# Altium schematic sheet interface_com_usuario.SchDoc
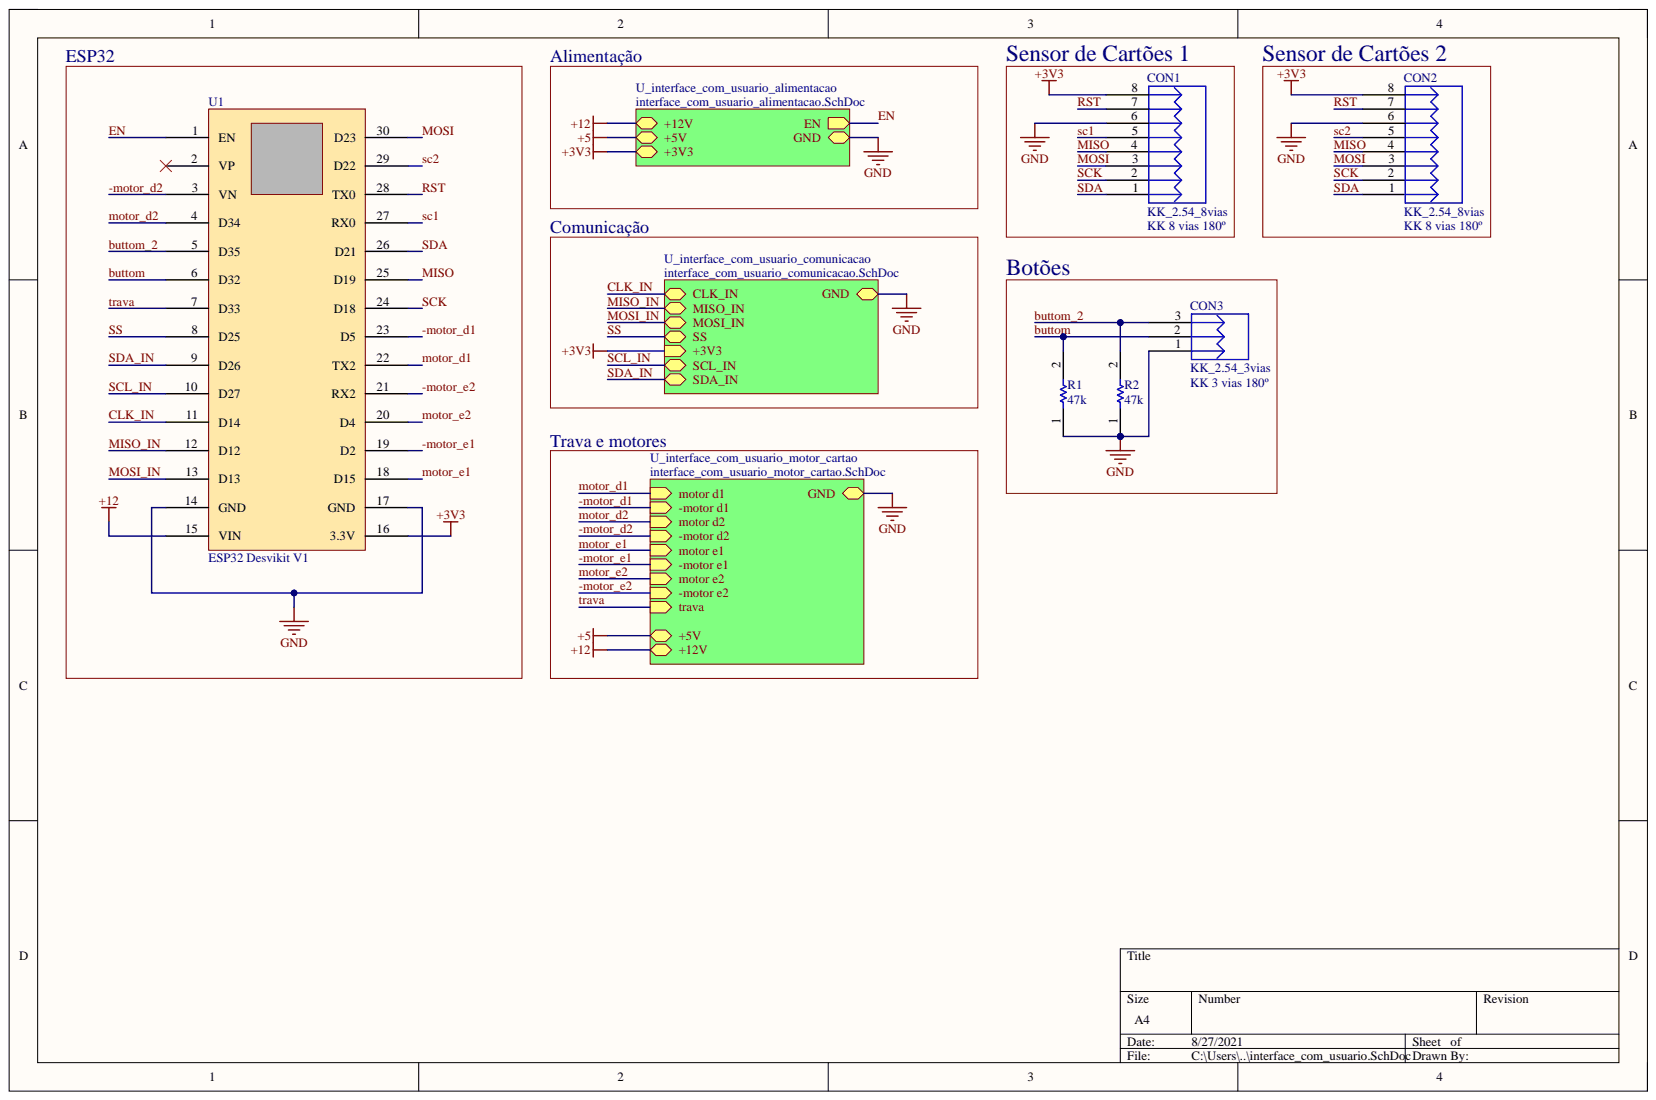
[diagram p0: interface_com_usuario.SchDoc]

## Parts
CON1  KK_2.54_8vias  Valor: KK 8 vias 180º  [KK_8vias_180°]
CON2  KK_2.54_8vias  Valor: KK 8 vias 180º  [KK_8vias_180°]
CON3  KK_2.54_3vias  Valor: KK 3 vias 180º  [KK_3vias_180º]
R1  47k  [RESC3216X60N]
R2  47k  [RESC3216X60N]
U1  value ESP32 Desvikit V1  [ESP32_Desvikit_v1]  (hidden comment: microcontrolador)

## Nets
+3V3: CON1.8 CON2.8 U1.16(3.3V)
+12: U1.15(VIN)
GND: CON1.6 CON2.6 CON3.1 R1.1 R2.1 U1.14(GND) U1.17(GND)
-motor_d1: U1.23(D5)
-motor_d2: U1.3(VN)
-motor_e1: U1.19(D2)
-motor_e2: U1.21(RX2)
buttom: CON3.2 R1.2 U1.6(D32)
buttom_2: CON3.3 R2.2 U1.5(D35)
CLK_IN: U1.11(D14)
EN: U1.1(EN)
MISO: CON1.4 CON2.4 U1.25(D19)
MISO_IN: U1.12(D12)
MOSI: CON1.3 CON2.3 U1.30(D23)
MOSI_IN: U1.13(D13)
motor_d1: U1.22(TX2)
motor_d2: U1.4(D34)
motor_e1: U1.18(D15)
motor_e2: U1.20(D4)
RST: CON1.7 CON2.7 U1.28(TX0)
sc1: CON1.5 U1.27(RX0)
sc2: CON2.5 U1.29(D22)
SCK: CON1.2 CON2.2 U1.24(D18)
SCL_IN: U1.10(D27)
SDA: CON1.1 CON2.1 U1.26(D21)
SDA_IN: U1.9(D26)
SS: U1.8(D25)
trava: U1.7(D33)
NetU1_2: U1.2(VP)
+5:

## Library data of the parts
CON1 CON2 = KK_2.54_8vias: Conector KK 2.54mm 8 vias; Descrição: Conector KK 3 vias com passo 2.54mm; Encapsulamento: PTH - 180º; Modelo: 3 vias - 180º; Component Kind: Standard; Library Name: Conectores_Sch.SchLib
CON3 = KK_2.54_3vias: Conector KK 2.54mm 3 vias; Descrição: Conector KK 3 vias com passo 2.54mm; Encapsulamento: PTH - 180º; Modelo: 3 vias - 180º; Component Kind: Standard; Library Name: Conectores_Sch.SchLib
R1 R2 = RES 1206: RES 1206 5%; Manufacturer_Name: Vishay; Manufacturer_Part_Number: CRCW12064K70FKEA; Arrow Part Number: CRCW12064K70FKEA; Arrow Price/Stock: https://www.arrow.com/en/products/crcw12064k70fkea/vishay; Component Kind: Standard; Datasheet Link: http://docs-emea.rs-online.com/webdocs/13ec/0900766b813ecc08.pdf; Height: 0.6mm; Mouser Part Number: 71-CRCW1206-4.7K-E3; Mouser Price/Stock: https://www.mouser.co.uk/ProductDetail/Vishay-Dale/CRCW12064K70FKEA?qs=1vm4qhDHCvlKJmatsKzyFQ%3D%3D; Library Name: RoboHospitalar.SchLib
U1 = ESP32 Desvikit v1: microcontrolador com moculo bluethoth e wifi; Component Kind: Standard; Library Name: Sinalização_Official.SchLib
